# Altium schematic sheet Sheet1.SchDoc
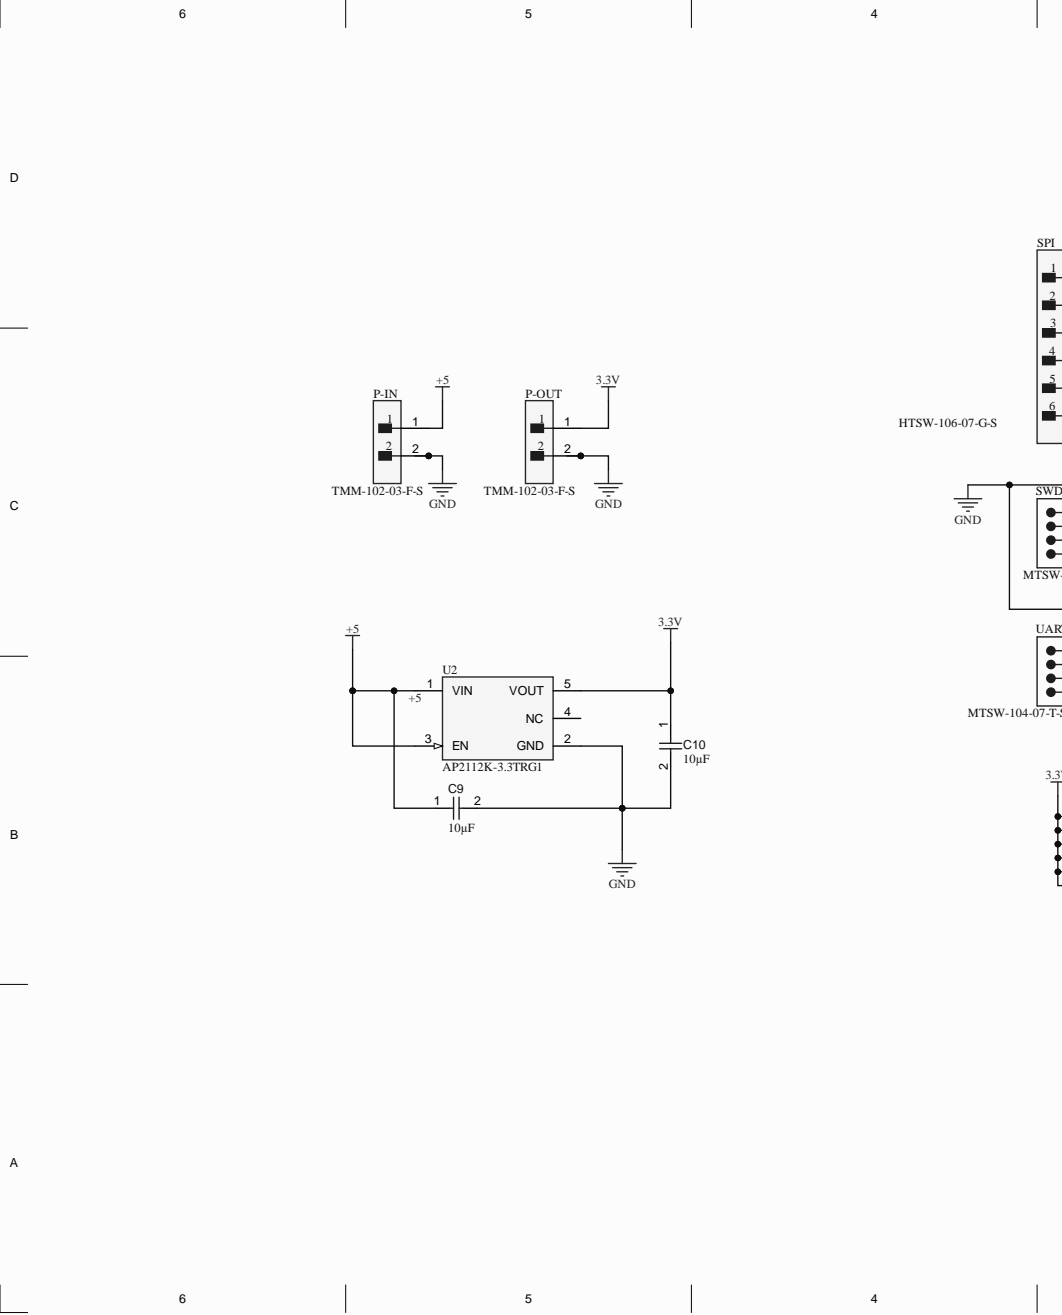
[diagram p0: Sheet1.SchDoc, left]
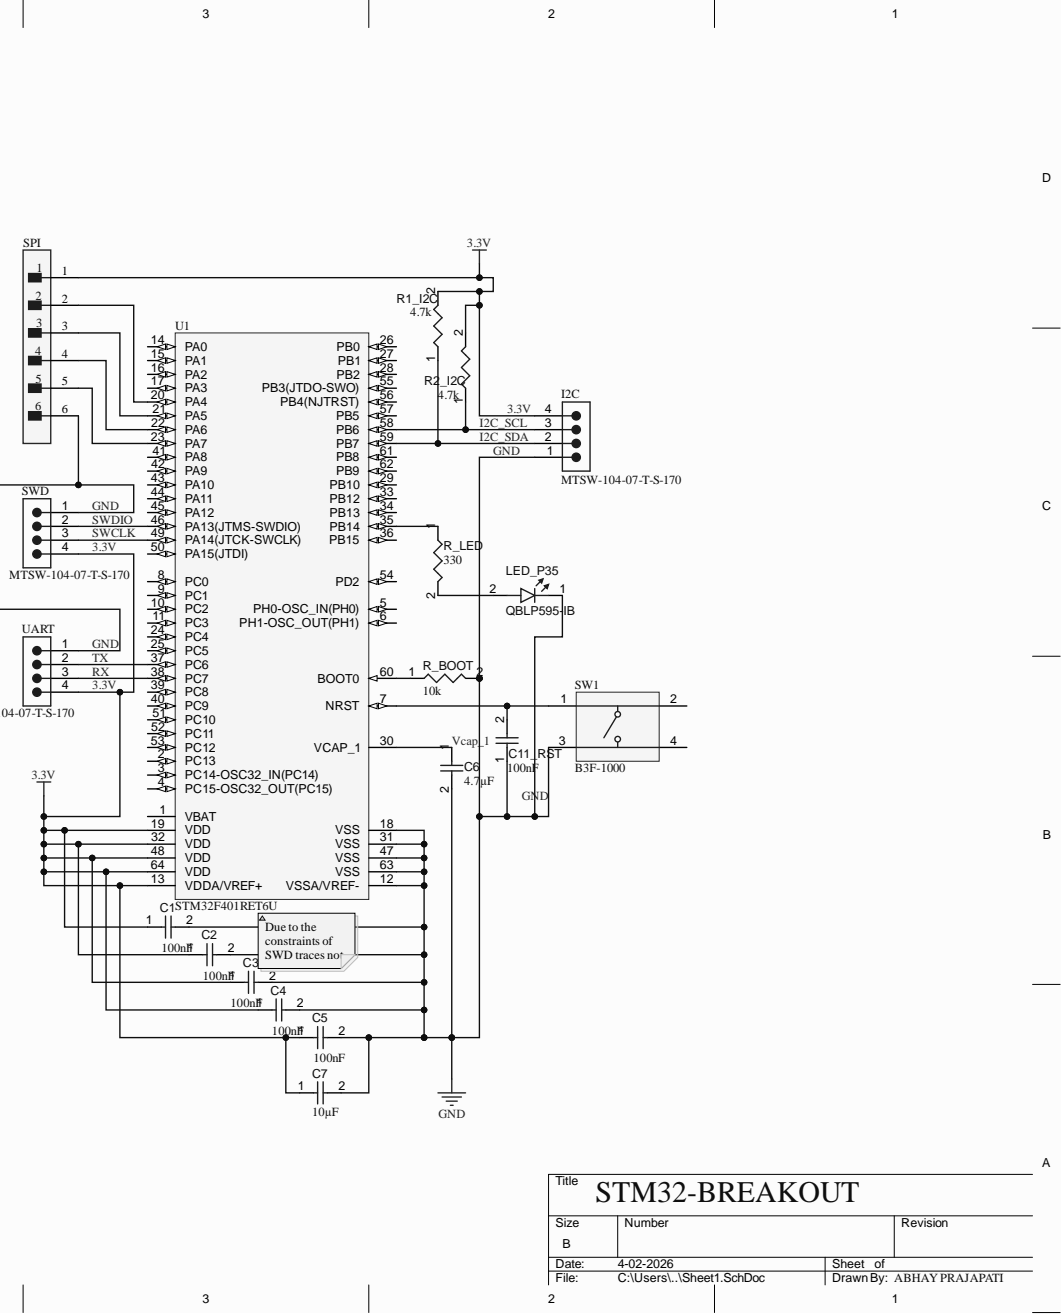
[diagram p1: Sheet1.SchDoc, right]

## Parts
C1  value 100nF  [CAPC0402(1005)60_L]  (hidden comment: Capacitor 100nF +/-20% 25V 0402)
C2  value 100nF  [CAPC0402(1005)60_L]  (hidden comment: Capacitor 100nF +/-20% 25V 0402)
C3_NOT  value 100nF  [CAPC0402(1005)60_L]  (hidden comment: Capacitor 100nF +/-20% 25V 0402)
C4  value 100nF  [CAPC0402(1005)60_L]  (hidden comment: Capacitor 100nF +/-20% 25V 0402)
C5  value 100nF  [CAPC0402(1005)60_L]  (hidden comment: Capacitor 100nF +/-20% 25V 0402)
C6  value 4.7µF  [CAPC0603(1608)100_L]  (hidden comment: Capacitor 4.7µF +/-20% 10V 0603)
C7  value 10µF  [FP-GRM21B-0_1-IPC_B]  (hidden comment: GRM21BR61C106KE15K)
C9  value 10µF  [CAPC2013X135X45NL10T25]  (hidden comment: Capacitor 10 uF +/- 10% 16 V 0805)
C10  value 10µF  [CAPC2013X135X45NL10T25]  (hidden comment: Capacitor 10 uF +/- 10% 16 V 0805)
C11_RST  value 100nF  [CAPC1608X90X40NL10T20]  (hidden comment: Capacitor 100 nF +/-10% 50 V 0603)
I2C  MTSW-104-07-T-S-170  [SMTC-MTSW-104-07-X-S-170]
LED_P35  QBLP595-IB  [QTB-QBLP595_V]
P-IN  TMM-102-03-F-S  [FP-TMM-102-03-F-S-MFG]
P-OUT  TMM-102-03-F-S  [FP-TMM-102-03-F-S-MFG]
R1_I2C  value 4.7k  [RESC0402(1005)_L]  (hidden comment: 4D7KR2F)
R2_I2C  value 4.7k  [RESC0402(1005)_L]  (hidden comment: 4D7KR2F)
R_BOOT  value 10k  [RESC3216X60X45ML15T20]  (hidden comment: Resistor 10k +/-1% 1206 250 mW)
R_LED  value 330  [RESC0402(1005)_L]  (hidden comment: 330R2J)
SPI  HTSW-106-07-G-S  [FP-HTSW-106-07-G-S-MFG]
SW1  B3F-1000  [OMRON-B3F-1000-4_V]
SWD  MTSW-104-07-T-S-170  [SMTC-MTSW-104-07-X-S-170]
U1  STM32F401RET6U  [STM-LQFP64_L]
U2  AP2112K-3.3TRG1  [FP-SOT25-IPC_B]
UART  MTSW-104-07-T-S-170  [SMTC-MTSW-104-07-X-S-170]

## Nets
3.3V: C1.1 C2.1 C3_NOT.1 C4.1 C5.1 C7.1 C10.1 I2C.4 P-OUT.1 R1_I2C.2 R2_I2C.2 SPI.1 SWD.4 U1.13(VDDA/VREF+) U1.19(VDD) U1.32(VDD) U1.48(VDD) U1.64(VDD) U2.5(VOUT) UART.4
+5: C9.1 P-IN.1 U2.1(VIN) U2.3(EN)
GND: C1.2 C2.2 C3_NOT.2 C4.2 C5.2 C6.2 C7.2 C9.2 C10.2 C11_RST.1 I2C.1 LED_P35.1 P-IN.2 P-OUT.2 R_BOOT.2 SPI.6 SW1.3 SWD.1 U1.12(VSSA/VREF-) U1.18(VSS) U1.31(VSS) U1.47(VSS) U1.63(VSS) U2.2(GND) UART.1
I2C_SCL: I2C.3 R2_I2C.1 U1.58(PB6)
I2C_SDA: I2C.2 R1_I2C.1 U1.59(PB7)
RX: U1.38(PC7) UART.3
SWCLK: SWD.3 U1.49(PA14(JTCK-SWCLK))
SWDIO: SWD.2 U1.46(PA13(JTMS-SWDIO))
TX: U1.37(PC6) UART.2
Vcap_1: C6.1 U1.30(VCAP_1)
NetC11_RST_2: C11_RST.2 SW1.1 U1.7(NRST)
NetLED_P35_2: LED_P35.2 R_LED.2
NetR_BOOT_1: R_BOOT.1 U1.60(BOOT0)
NetR_LED_1: R_LED.1 U1.35(PB14)
NetSPI_2: SPI.2 U1.20(PA4)
NetSPI_3: SPI.3 U1.21(PA5)
NetSPI_4: SPI.4 U1.22(PA6)
NetSPI_5: SPI.5 U1.23(PA7)
NetSW1_2: SW1.2
NetSW1_4: SW1.4
NetU1_1: U1.1(VBAT)
NetU1_2: U1.2(PC13)
NetU1_3: U1.3(PC14-OSC32_IN(PC14))
NetU1_4: U1.4(PC15-OSC32_OUT(PC15))
NetU1_5: U1.5(PH0-OSC_IN(PH0))
NetU1_6: U1.6(PH1-OSC_OUT(PH1))
NetU1_8: U1.8(PC0)
NetU1_9: U1.9(PC1)
NetU1_10: U1.10(PC2)
NetU1_11: U1.11(PC3)
NetU1_14: U1.14(PA0)
NetU1_15: U1.15(PA1)
NetU1_16: U1.16(PA2)
NetU1_17: U1.17(PA3)
NetU1_24: U1.24(PC4)
NetU1_25: U1.25(PC5)
NetU1_26: U1.26(PB0)
NetU1_27: U1.27(PB1)
NetU1_28: U1.28(PB2)
NetU1_29: U1.29(PB10)
NetU1_33: U1.33(PB12)
NetU1_34: U1.34(PB13)
NetU1_36: U1.36(PB15)
NetU1_39: U1.39(PC8)
NetU1_40: U1.40(PC9)
NetU1_41: U1.41(PA8)
NetU1_42: U1.42(PA9)
NetU1_43: U1.43(PA10)
NetU1_44: U1.44(PA11)
NetU1_45: U1.45(PA12)
NetU1_50: U1.50(PA15(JTDI))
NetU1_51: U1.51(PC10)
NetU1_52: U1.52(PC11)
NetU1_53: U1.53(PC12)
NetU1_54: U1.54(PD2)
NetU1_55: U1.55(PB3(JTDO-SWO))
NetU1_56: U1.56(PB4(NJTRST))
NetU1_57: U1.57(PB5)
NetU1_61: U1.61(PB8)
NetU1_62: U1.62(PB9)
NetU2_4: U2.4(NC)

## Library data of the parts
C1 C2 C3_NOT C4 C5 = CMP-001-00065-4: Chip Capacitor, 100nF +/-20%, 25V, 0402, Thickness 0.6 mm; Tolerance: 10%; Case/Package: 0402; Component Kind: Standard; Max Operating Temperature: 85°C; Min Operating Temperature: -55°C; Mounting Technology: SMT; Pins: 2; Voltage Rating: 25V; Library Name: Abhay Prajapati
C6 = CMP-001-00076-4: Chip Capacitor, 4.7µF +/-20%, 10V, 0603, Thickness 1 mm; Tolerance: 10%; Case/Package: 0603; Component Kind: Standard; Max Operating Temperature: 85°C; Min Operating Temperature: -55°C; Mounting Technology: SMT; Pins: 2; Voltage Rating: 10V; Library Name: Abhay Prajapati
C7 = CMP-001-00083-1: 16V 10uF X5R ±10% 0805 Multilayer Ceramic Capacitors MLCC - SMD/SMT ROHS; Case/Package: 0805; Component Kind: Standard; Max Operating Temperature: 85°C; Min Operating Temperature: -55°C; Mounting Technology: Surface Mount; Tolerance: 10%; Voltage Rating: 16V; Library Name: Abhay Prajapati
C9 C10 = CMP-001-00015-6: Chip Capacitor, 10 uF, +/- 10%, 16 V, 0805 (2012 Metric); Capacitor Type: Ceramic; Voltage Rating: 16V; Case/Package: 0805; Component Kind: Standard; Max Operating Temperature: 85°C; Min Operating Temperature: -55°C; Mounting Technology: SM; Pins: 2; RoHS Compliant: True; Tolerance: 10%; Library Name: Abhay Prajapati
C11_RST = CMP-001-00017-6: Chip Capacitor, 100 nF, +/- 10%, 50 V, 0603 (1608 Metric); Capacitor Type: Ceramic; Voltage Rating: 50V; Case/Package: 0603; Component Kind: Standard; Max Operating Temperature: 125°C; Min Operating Temperature: -55°C; Mounting Technology: SM; Pins: 2; RoHS Compliant: True; Tolerance: 10%; Library Name: Abhay Prajapati
I2C SWD UART = CMP-002-00075-1: Headers & Wire Housings Variable Height PCB Header Strips, 0.100 pitch; Component Kind: Standard; Library Name: Abhay Prajapati
LED_P35 = CMP-007-00042-3: Chip LED 0402, Blue, 0.02 A, 3.1 to 3.7 V, -40 to 80 degC, 2-Pin SMD, RoHS, Tape and Reel; Case/Package: 0402; Colour: Blue; Component Kind: Standard; Mounting Technology: SMT; Pins: 2; RoHS Compliant: Yes; Library Name: Abhay Prajapati
P-IN P-OUT = CMP-002-00077-1: Pin Header, Board-to-Board, Wire-to-Board, 2 mm, 1 Rows, 2 Contacts, Through Hole, TMM; Component Kind: Standard; Library Name: Abhay Prajapati
R1_I2C R2_I2C = CMP-009-00105-2: 4K7 0.063W 1% 0402 (1005 Metric)  SMD; Power: 63mW; Case/Package: 0402; Component Kind: Standard; Mounting Technology: SMT; Pins: 2; Tolerance: 1%; Library Name: Abhay Prajapati
R_BOOT = CMP-009-00016-5: Chip Resistor, 10 KOhm, +/- 1%, 0.25 W, -55 to 155 degC, 1206 (3216 Metric); Power: 250mW; Voltage Rating: 200V; Case/Package: 1206; Component Kind: Standard; Max Operating Temperature: 155°C; Min Operating Temperature: -55°C; Mounting Technology: SM; Pins: 2; RoHS Compliant: True; Tolerance: 1%; Library Name: Abhay Prajapati
R_LED = CMP-009-00095-2: 330R 0.063W 5% 0402 (1005 Metric)  SMD; Power: 63mW; Case/Package: 0402; Component Kind: Standard; Mounting Technology: SMT; Pins: 2; Tolerance: 5%; Library Name: Abhay Prajapati
SPI = CMP-002-00078-1: Pin Header, Vertical, Board-to-Board, 2.54 mm, 1 Rows, 6 Contacts, Through Hole, HTSW Series; Component Kind: Standard; Library Name: Abhay Prajapati
SW1 = CMP-011-00004-2: Tactile Switch, SPST-NO, 0.05 A, 24 V, -25 to 70 degC, 4-Pin THD, RoHS, Bulk; Manufacturer: Omron Electronic Components; Contact Current Rating: 50mA; Max Contact Resistance: 100mohm; Max Voltage Rating (DC): 24V; Operating Force: 100gf; Resistance: 0.1Ohm; Throw Configuration: SPST; Case/Package: B3F-1000; Component Kind: Standard; Contact Material: Gold; Contact Plating: Gold; Current Rating: 50mA; Datasheet URL: http://www.omron.com/ecb/products/pdf/en-b3f.pdf; Datasheet Version: Jan-15; Manufacturer URL: http://www.omron.com/; Max Current Rating: 50mA; Max Operating Temperature: 70°C; Min Operating Temperature: -25°C; Mounting Technology: TH; Package Description: 4-Pin Through Hole Device, Body 6 x 6 mm; Package Quantity: 1500; Package Reference: B3F-1000; Packaging: Bulk; Pins: 4; RoHS Compliant: True; Voltage Rating: 24V; Voltage Rating (DC): 24V; Library Name: Abhay Prajapati
U1 = CMP-00000-1: MCU 32-Bit STM32 ARM Cortex M4 RISC 512KB Flash 2.5V/3.3V 64-Pin LQFP Tray; Frequency: 84MHz; Max Supply Voltage: 3.6V; Min Supply Voltage: 1.7V; Number of I/Os: 50; RAM Size: 96kB; Case/Package: LQFP; Component Kind: Standard; Memory Size: 512kB; Packaging: Cut Tape; Pins: 64; REACH SVHC: No; RoHS: Compliant; Library Name: Abhay Prajapati
U2 = CMP-019-00049-1: AP2112 Series 0.6 A 3.3 V Fixed Output SMT LDO Linear Regulator - SOT23-5; Component Kind: Standard; Library Name: Abhay Prajapati
